# Altium schematic sheet Pulse_WS_MAX30105.SchDoc(Pulse_WS_MAX30105)
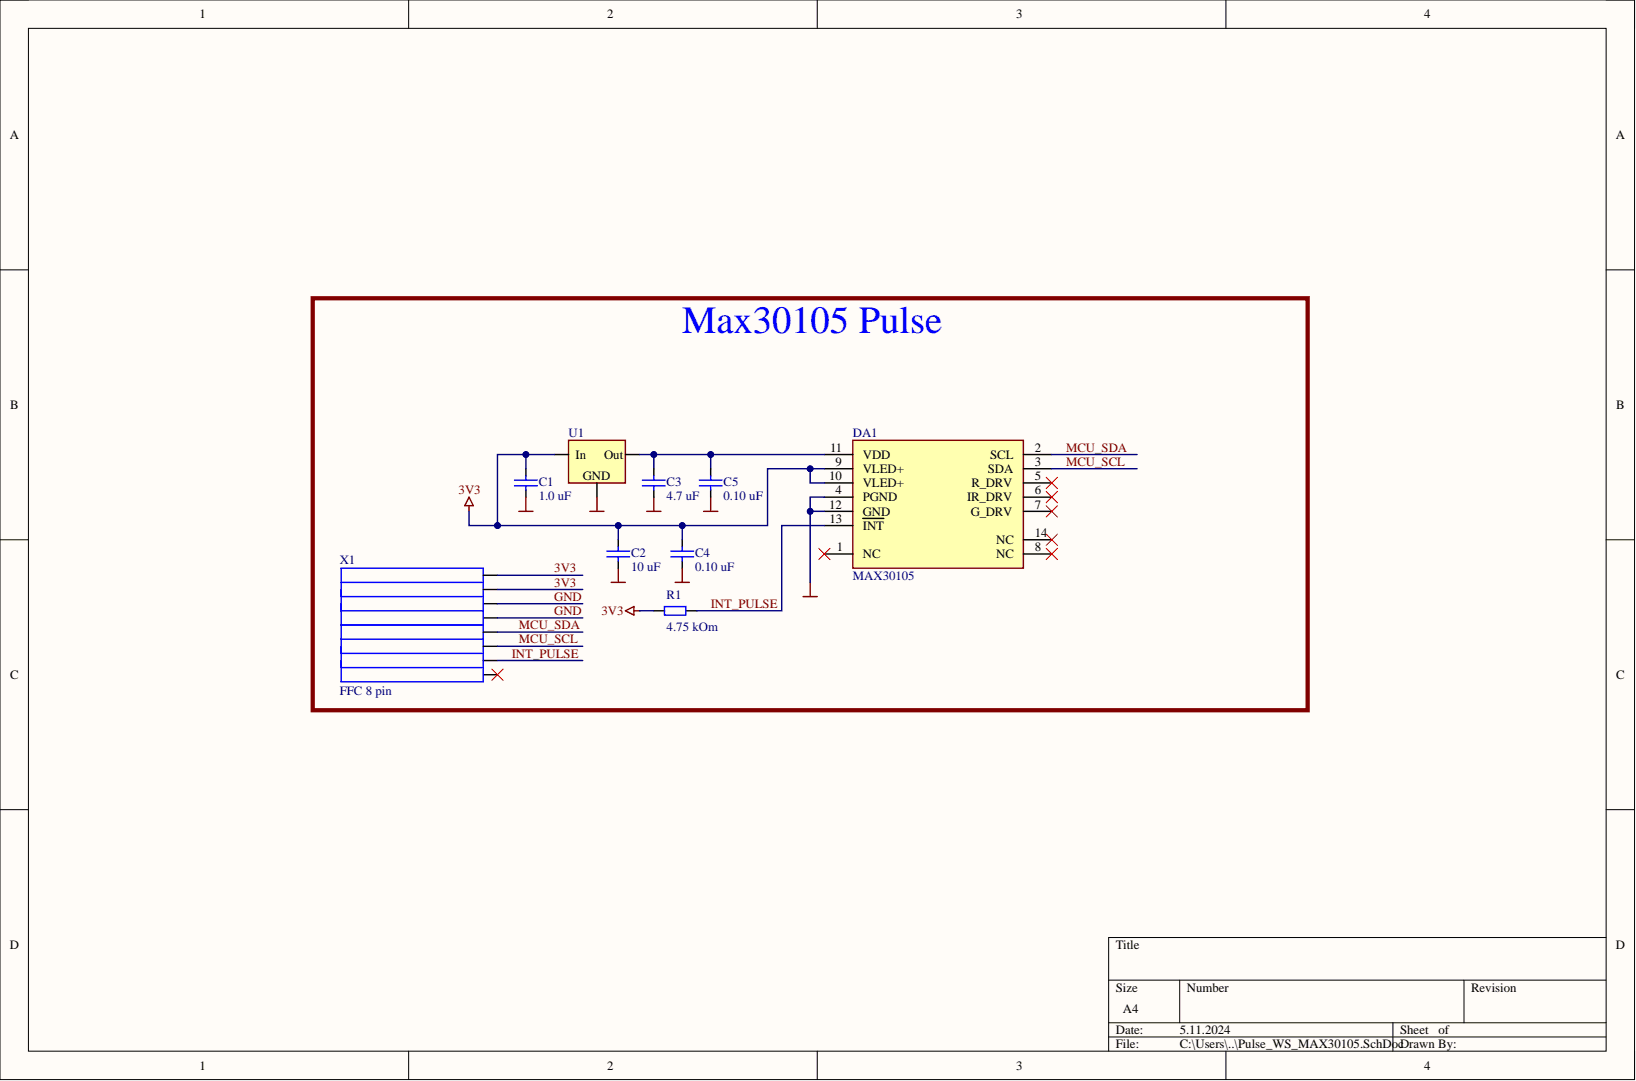
[diagram p0: Pulse_WS_MAX30105.SchDoc]

## Parts
C1  value 1.0 uF  [CAPC1608X90N]  (hidden comment: STD_CAP)
C2  value 10 uF  [CAPC2012X120N]  (hidden comment: STD_CAP)
C3  value 4.7 uF  [CAPC2012X120N]  (hidden comment: STD_CAP)
C4  value 0.10 uF  [CAPC1005X55N]  (hidden comment: STD_CAP)
C5  value 0.10 uF  [CAPC1005X55N]  (hidden comment: STD_CAP)
DA1  MAX30105  [MAX30105]
R1  value 4.75 kOm  [RESC1005X40N]  (hidden comment: STD_RES)
U1  XC6206P182MR  [SOT23]  (value not drawn)
X1  FFC 8 pin  [68710814022]

## Nets
3V3: C1.1 C2.1 C4.1 DA1.9(VLED+) DA1.10(VLED+) R1.1 U1.3 X1.1 X1.2
GND: C1.2 C2.2 C3.2 C4.2 C5.2 DA1.4(PGND) DA1.12(GND) U1.1 X1.3 X1.4
INT_PULSE: DA1.13(INT) R1.2 X1.7
MCU_SCL: DA1.3(SDA) X1.6
MCU_SDA: DA1.2(SCL) X1.5
NetC3_1: C3.1 C5.1 DA1.11(VDD) U1.2

Not connected: DA1 1(NC) 5(R_DRV) 6(IR_DRV) 7(G_DRV) 8(NC) 14(NC); X1 8

## Library data of the parts
C1 = 3936: Manufacturer: muRata; ManufacturerPartnumber: GRM188R71C105KA12D; BOM: Конденсатор 0603 1.0 uF ±10% 16V X7R    GRM188R71C105KA12D muRata; Comp_Class_Name: Capacitors Chip SMD 0603; Comp_Class_Number: 200004; Comp_Description: Capacitors 0603 1.0 uF ±10% 16V X7R; Comp_ID: 200004-0109; Database Table Name: Comp_All; Dielectric: X7R; Human_Readable: Конденсатор 0603 1.0 uF ±10% 16V X7R; Lib_Description: Capacitor; Precision: ±10%; Temp_Range: -55 to 125°C; Value: 1.0 uF; Voltage_Range: 16V; Component Kind: Standard; ComponentLink1Description: MANUFACTURER_URL; ComponentLink1URL: http://www.murata.com/products/catalog/pdf/c02e.pdf; Footprint_Description: Chip Capacitor EIA 0603. METRIC 1608, 2-Leads, Body 1,6x0,8mm, IPC Medium Density; HelpURL: \\corp1\RNDlibrary\_Library\Altium_lib\Datasheet\muRata\Chip_Monolithic_Ceramic_Capacitors\Chip_Monolithic_Ceramic_Capacitors.pdf; Library Name: Altium_lib.SVNDbLib; Number_Base: 3936; Price: 0,0799999982118607; TimeModification_Comp: 06.12.2011 14:46:17
C2 = 4014: Manufacturer: muRata; ManufacturerPartnumber: GRM21BR71A106KE51L; BOM: Конденсатор 0805 10 uF ±10% 10V X7R    GRM21BR71A106KE51L muRata; Comp_Class_Name: Capacitors Chip SMD 0805; Comp_Class_Number: 200005; Comp_Description: Capacitors 0805 10 uF ±10% 10V X7R; Comp_ID: 200005-0069; Database Table Name: Comp_All; Dielectric: X7R; Human_Readable: Конденсатор 0805 10 uF ±10% 10V X7R; Lib_Description: Capacitor; Precision: ±10%; Temp_Range: -55 to 125°C; Voltage_Range: 10V; Component Kind: Standard; ComponentLink1Description: MANUFACTURER_URL; ComponentLink1URL: http://www.murata.com/products/catalog/pdf/c02e.pdf; Footprint_Description: Chip Capacitor EIA 0805. METRIC 2012, 2-Leads, Body 2,0x1,2mm, IPC Medium Density; HelpURL: \\corp1\RNDlibrary\_Library\Altium_lib\Datasheet\muRata\Chip_Monolithic_Ceramic_Capacitors\Chip_Monolithic_Ceramic_Capacitors.pdf; Library Name: Altium_lib.SVNDbLib; Number_Base: 4014; TimeModification_Comp: 07.12.2011 12:55:51
C3 = 4013: Manufacturer: muRata; ManufacturerPartnumber: GRM21BR71A475KA73L; BOM: Конденсатор 0805 4.7 uF ±10% 10V X7R    GRM21BR71A475KA73L muRata; Comp_Class_Name: Capacitors Chip SMD 0805; Comp_Class_Number: 200005; Comp_Description: Capacitors 0805 4.7 uF ±10% 10V X7R; Comp_ID: 200005-0068; Database Table Name: Comp_All; Dielectric: X7R; Human_Readable: Конденсатор 0805 4.7 uF ±10% 10V X7R; Lib_Description: Capacitor; Precision: ±10%; Temp_Range: -55 to 125°C; Value: 4.7 uF; Voltage_Range: 10V; Component Kind: Standard; ComponentLink1Description: MANUFACTURER_URL; ComponentLink1URL: http://www.murata.com/products/catalog/pdf/c02e.pdf; Footprint_Description: Chip Capacitor EIA 0805. METRIC 2012, 2-Leads, Body 2,0x1,2mm, IPC Medium Density; HelpURL: \\corp1\RNDlibrary\_Library\Altium_lib\Datasheet\muRata\Chip_Monolithic_Ceramic_Capacitors\Chip_Monolithic_Ceramic_Capacitors.pdf; Library Name: Altium_lib.SVNDbLib; Number_Base: 4013; TimeModification_Comp: 07.12.2011 12:55:51
C4 C5 = 3823: Manufacturer: muRata; ManufacturerPartnumber: GRM155R71C104KA88D; AuthorModification_Comp: anosova; BOM: Конденсатор 0402 0.10 uF ±10% 16V X7R    GRM155R71C104KA88D muRata; Comp_Class_Name: Capacitors Chip SMD 0402; Comp_Class_Number: 200003; Comp_Description: Capacitors 0402 0.10 uF ±10% 16V X7R; Comp_ID: 200003-0422; Database Table Name: Comp_All; Dielectric: X7R; Human_Readable: Конденсатор 0402 0.10 uF ±10% 16V X7R; Lib_Description: Capacitor; Precision: ±10%; Temp_Range: -55 to 125°C; Voltage_Range: 16V; Component Kind: Standard; ComponentLink1Description: MANUFACTURER_URL; ComponentLink1URL: http://www.murata.com/products/catalog/pdf/c02e.pdf; Footprint_Description: Chip Capacitor EIA 0402. METRIC 1005, 2-Leads, Body 1,00x0,5mm, IPC Medium Density; HelpURL: \\corp1\RNDlibrary\_Library\Altium_lib\Datasheet\muRata\Chip_Monolithic_Ceramic_Capacitors\Chip_Monolithic_Ceramic_Capacitors.pdf; Library Name: Altium_lib.SVNDbLib; Number_Base: 3823; Price: 0,0199999995529652; TimeModification_Comp: 18.11.2021 13:09:14
DA1 = MAX30105: Manufacturer: MAX; BOM: Датчик пульса    MPU-9250 MAX; Human_Readable: Датчик пульса; Component Kind: Standard; ComponentLink1Description: Help; Footprint_Description: Custom; ManufacturerPartnumber: MPU-9250; ComponentLink1URL: D:\HSE\Трекер физ состояния\Help\MAX30105.pdf; HelpURL: D:\HSE\Трекер физ состояния\Help\MAX30105.pdf; Library Name: SCH_Wearing_sensor.SchLib
R1 = 2904: Manufacturer: Yageo; ManufacturerPartnumber: RC0402FR-074K75L; BOM: Резистор 0402 1% E96 4,75 кОм    RC0402FR-074K75L Yageo; Comp_Class_Name: Resistors Chip SMD 0402 1% E96; Comp_Class_Number: 11010201; Comp_Description: Resistor 0402 1% E96 4.75 kOm; Comp_ID: 11010201-354; Database Table Name: Comp_All; Human_Readable: Резистор 0402 1% E96 4,75 кОм; Lib_Description: Resistor; Power_Range: 0.0625W; Precision: 1%; Temp_Range: -55 °C to +155 °C; Component Kind: Standard; ComponentLink1Description: MANUFACTURER_URL; ComponentLink1URL: http://www.yageo.com/documents/recent/PYu-RC0402_51_RoHS_L_6.pdf; Footprint_Description: Chip Resistor EIA 0402. METRIC 1005, 2-Leads, Body 1,00x0,5mm, IPC Medium Density; HelpURL: \\corp1\RNDlibrary\_Library\Altium_lib\Datasheet\Yageo\RC0402\PYu-RC0402_51_RoHS_L_6.pdf; Library Name: Altium_lib.SVNDbLib; Number_Base: 2904; Price: 0,00600000005215406; TimeModification_Comp: 06.12.2011 13:34:56
U1 = XC6206P182MR: LDO 1v8; Manufacturer: UMW; BOM: LDO 1V8    XC6206P182MR UMW; Component Kind: Standard; Footprint_Description: SOT23; Human_Readable: LDO 1V8; ManufacturerPartnumber: XC6206P182MR; Library Name: SCH_Wearing_sensor.SchLib
X1 = FFC 8 pin: FFC connector 8 pin; Component Kind: Standard; Library Name: SCH_Wearing_sensor.SchLib
